# Altium schematic sheet 5 - PWM Steering.SchDoc
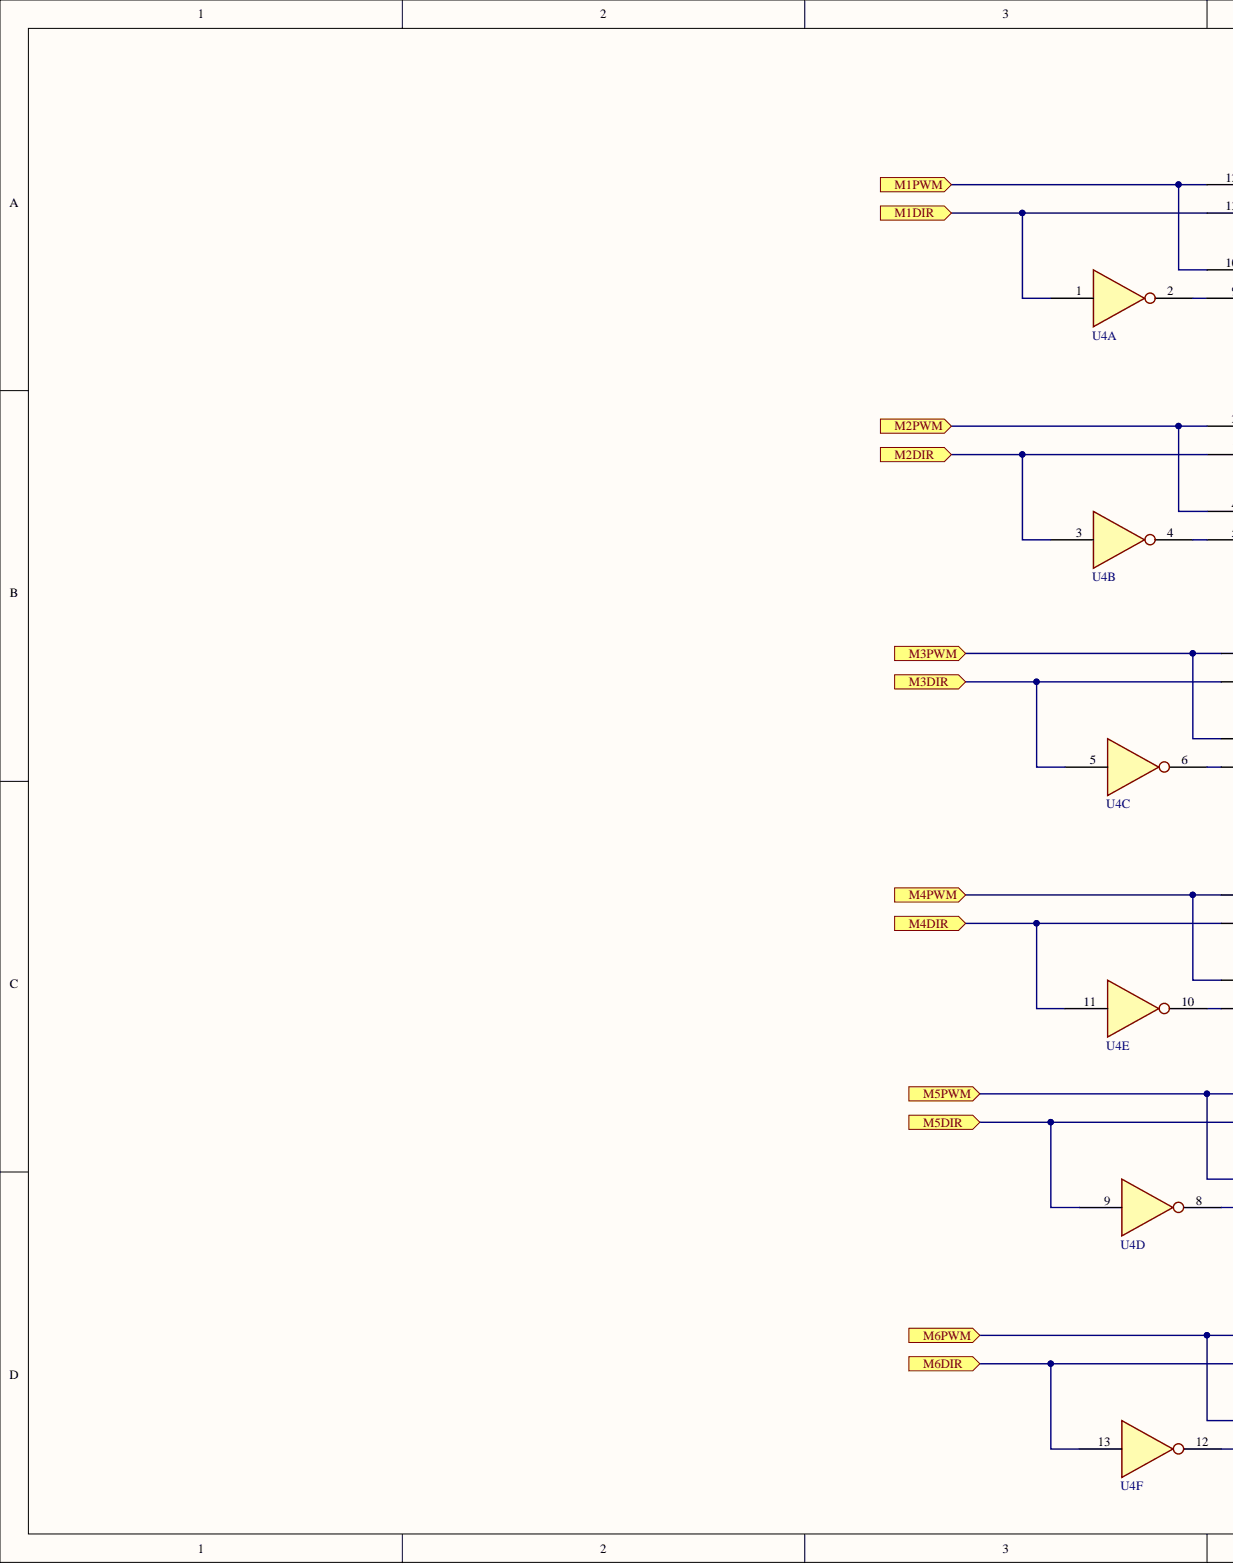
[diagram p0: 5 - PWM Steering.SchDoc, left]
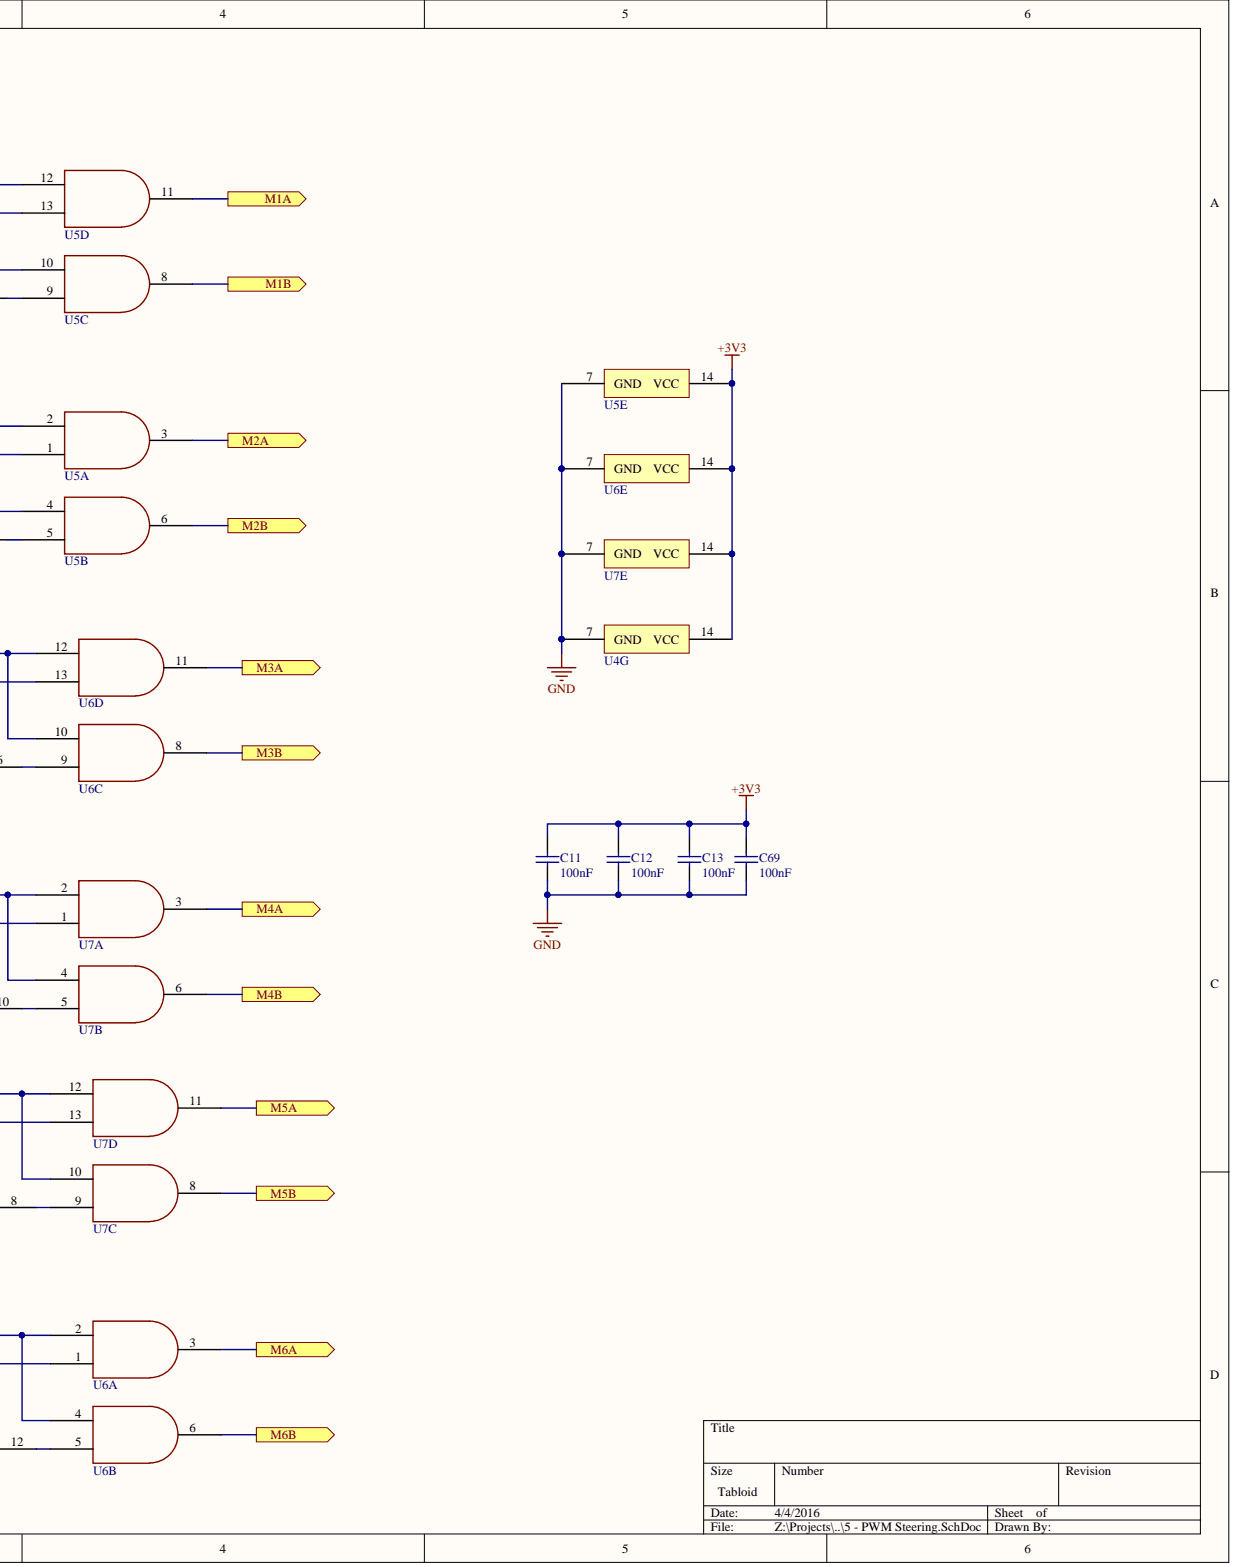
[diagram p1: 5 - PWM Steering.SchDoc, right]

## Parts
C11  value 100nF  [CAPC0805(2012)100_L]  (hidden comment: CAP 100nF 6.3V 0805(2012))
C12  value 100nF  [CAPC0805(2012)100_L]  (hidden comment: CAP 100nF 6.3V 0805(2012))
C13  value 100nF  [CAPC0805(2012)100_L]  (hidden comment: CAP 100nF 6.3V 0805(2012))
C69  value 100nF  [CAPC0805(2012)100_L]  (hidden comment: CAP 100nF 6.3V 0805(2012))
U4  Hex Inverter  [QFN50P300X250X100-15T110X160N]  (value not drawn)  (parts A,B,C,D,E,F,G)
U5  Quad 2-Input AND Gate  [QFN50P300X250X100-15T110X160N]  (value not drawn)  (parts A,B,C,D,E)
U6  Quad 2-Input AND Gate  [QFN50P300X250X100-15T110X160N]  (value not drawn)  (parts A,B,C,D,E)
U7  Quad 2-Input AND Gate  [QFN50P300X250X100-15T110X160N]  (value not drawn)  (parts A,B,C,D,E)

## Ports
M1A, M1B, M1DIR, M1PWM, M2A, M2B, M2DIR, M2PWM, M3A, M3B, M3DIR, M3PWM, M4A, M4B, M4DIR, M4PWM, M5A, M5B, M5DIR, M5PWM, M6A, M6B, M6DIR, M6PWM

## Nets
+3V3: C11.2 C12.2 C13.2 C69.2 U4.14(VCC) U5.14(VCC) U6.14(VCC) U7.14(VCC)
GND: C11.1 C12.1 C13.1 C69.1 U4.7(GND) U5.7(GND) U6.7(GND) U7.7(GND)
M1A: U5.11 ; port M1A
M1B: U5.8 ; port M1B
M1DIR: U4.1 U5.13 ; port M1DIR
M1PWM: U5.10 U5.12 ; port M1PWM
M2A: U5.3 ; port M2A
M2B: U5.6 ; port M2B
M2DIR: U4.3 U5.1 ; port M2DIR
M2PWM: U5.2 U5.4 ; port M2PWM
M3A: U6.11 ; port M3A
M3B: U6.8 ; port M3B
M3DIR: U4.5 U6.13 ; port M3DIR
M3PWM: U6.10 U6.12 ; port M3PWM
M4A: U7.3 ; port M4A
M4B: U7.6 ; port M4B
M4DIR: U4.11 U7.1 ; port M4DIR
M4PWM: U7.2 U7.4 ; port M4PWM
M5A: U7.11 ; port M5A
M5B: U7.8 ; port M5B
M5DIR: U4.9 U7.13 ; port M5DIR
M5PWM: U7.10 U7.12 ; port M5PWM
M6A: U6.3 ; port M6A
M6B: U6.6 ; port M6B
M6DIR: U4.13 U6.1 ; port M6DIR
M6PWM: U6.2 U6.4 ; port M6PWM
NetU4_2: U4.2 U5.9
NetU4_4: U4.4 U5.5
NetU4_6: U4.6 U6.9
NetU4_8: U4.8 U7.9
NetU4_10: U4.10 U7.5
NetU4_12: U4.12 U6.5

## Library data of the parts
C11-C13 C69 = CMP-1036-04391-1: CAP 100nF 6.3V Â±10% 0805 (2012 Metric) Thickness 1mm SMD; Case-EIA: 0805; Case-Metric: 2012; Component Kind: Standard; Library Name: Altium Content Vault; Max Thickness: 1 mm; Rated Voltage: 6.3 V; Technology: SMT; Tolerance: Â±10%
U4 = 74LVC04A: Component Kind: Standard; Description: Hex Inverter; Library Name: ak-misc.SCHLIB
U5-U7 = 74LVC08A: Component Kind: Standard; Description: Quad 2-Input AND Gate; Library Name: ak-misc.SCHLIB
